# Altium schematic sheet StepMotorAnd Triac.SchDoc
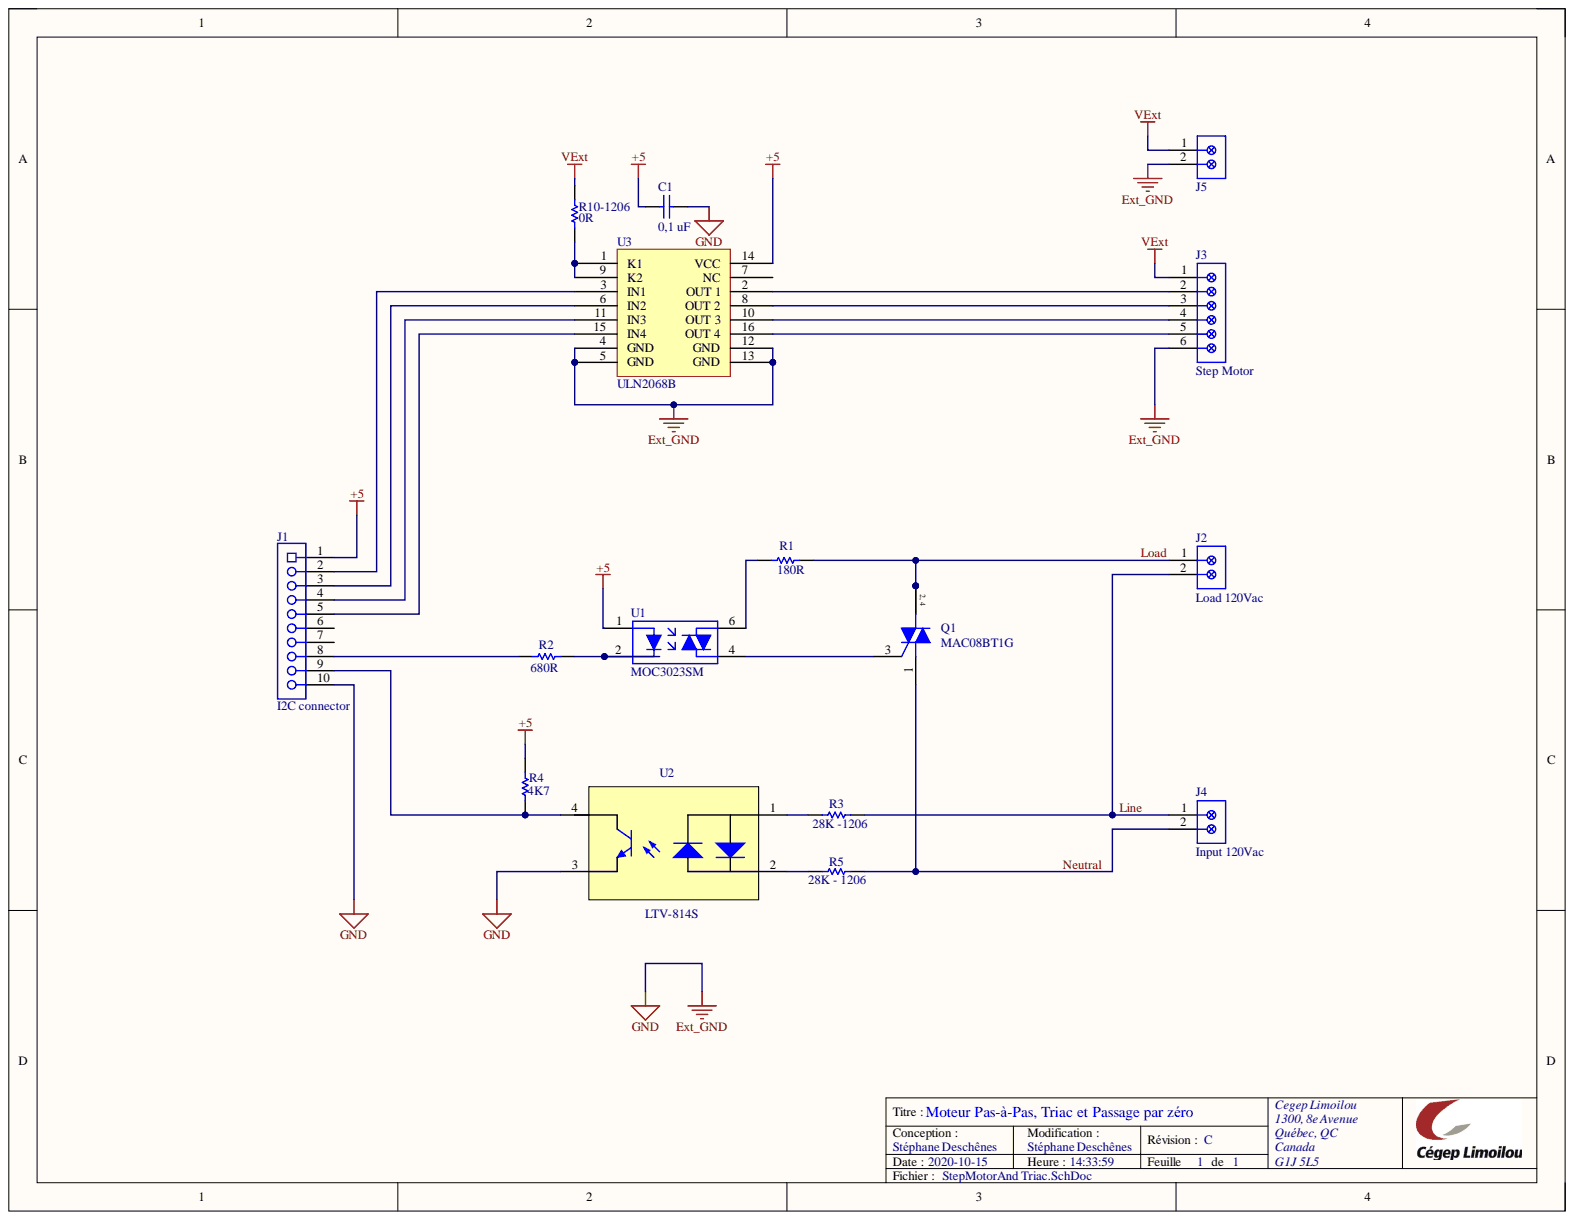
[diagram p0: StepMotorAnd Triac.SchDoc]

## Parts
C1  0,1 uF  [C0805]
J1  I2C connector  [PCB-m0n3ellhq5mdac1bt9wy-1]
J2  Load 120Vac  [SCREW-TERMINAL-2POS-0.2]
J3  Step Motor  [SCREW-TERMINAL-6POS-0.1]
J4  Input 120Vac  [PCB-1yya2zbeuka095bf8bhp-1]
J5  Screw Terminal, 0.1", 2 pos  [SCREW-TERMINAL-2POS-0.1]  (value not drawn)
Q1  MAC08BT1G  [SOT-223]
R1  180R  [R0805]
R2  680R  [R0805]
R3  28K -1206  [R1206]
R4  4K7  [R0805]
R5  28K - 1206  [R1206]
R10-1206  0R  [R1206]
U1  MOC3023SM  [PCB-12867618-1]
U2  LTV-814S  [SMD4-2.54-4.6X6.5MM]
U3  ULN2068B  [POWER DIP-16P]

## Nets
+5: C1.1 J1.1 R4.2 U1.1 U3.14(VCC)
Ext_GND: C1.2 J1.10 J3.6 J5.2 U2.3 U3.4(GND) U3.5(GND) U3.12(GND) U3.13(GND)
VExt: J3.1 J5.1 R10-1206.2
Line: J2.2 J4.1 R3.1
Load: J2.1 Q1.2 Q1.4 R1.2
Neutral: J4.2 Q1.1 R5.1
NetJ1_2: J1.2 U3.3(IN1)
NetJ1_3: J1.3 U3.6(IN2)
NetJ1_4: J1.4 U3.11(IN3)
NetJ1_5: J1.5 U3.15(IN4)
NetJ1_8: J1.8 R2.1
NetJ1_9: J1.9 R4.1 U2.4
NetJ3_2: J3.2 U3.2(OUT_1)
NetJ3_3: J3.3 U3.8(OUT_2)
NetJ3_4: J3.4 U3.10(OUT_3)
NetJ3_5: J3.5 U3.16(OUT_4)
NetQ1_3: Q1.3 U1.4
NetR1_1: R1.1 U1.6
NetR2_2: R2.2 U1.2
NetR3_2: R3.2 U2.1
NetR5_2: R5.2 U2.2
NetR10-1206_1: R10-1206.1 U3.1(K1) U3.9(K2)

Not connected: J1 6 7; U3 7(NC)

## Library data of the parts
C1 = Capacitor SMT: Manufacturer: Murata; Part Number: GRM21BR60J226ME39L; Component Kind: Standard; Library Name: Library-SD.SCHLIB
J1 = THT Vertical Pin Header, 10 pins: THT Vertical Pin Header WR-PHD, Pitch 2.54 mm, Single Row, 10 pins; Manufacturer Part Number: 61301011121; Contact Resistance: 20.0 mΩ; Dielectric Withstanding Voltage: 500 V; Gender: Male; Operating Voltage: 250 V; Pin Pitch: 2.54 mm; Component Kind: Standard; Library Name: Library-SD.SCHLIB; Number of Pins: 10; Octopart URL: https://octopart.com/61301011121-w%C3%BCrth+elektronik-18818159; Operating Temperature: -40.0 °C (min); Orientation: Vertical; Packaging: Bag; REACH SVHC Compliance: No SVHC; RoHS: Compliant
J2 J4 = CMP-751594-7: Conn Terminal Block 2 POS 5.08mm Solder ST Thru-Hole 16A; Component Kind: Standard; Contact Material: Brass; Mounting Style: Through Hole, Screw; OctopartID: 7e60b76ee18ea1a7; Packaging: Bulk; RoHS: Compliant
  J2: Library Name: Library-SD.SCHLIB
  J4: Library Name: CommunityVault
J3 = THT Vertical Pin Header, 6 pins: Conn Term Block 2.54mm 6pos Pcb; Component Kind: Standard; Library Name: Library-SD.SCHLIB
J5 = THT Vertical Pin Header, 2 pins: Conn Terminal Block 2 POS 2.54mm Solder ST Thru-Hole 6A; Color: Green; Component Kind: Standard; Library Name: Library-SD.SCHLIB; OctopartID: ad22650d0fb772e5
Q1 = MAC08: Sensitive Gate Triacs, Silicon Bidirectional Thyristor, 4-Pin SOT-223, Pb-Free, Tape and Reel; Current Rating: 0.8 A; Lead-Free Status: Lead Free; Voltage Rating (DC): 200.0 V; Case/Package: SOT-223; Component Kind: Standard; Halogen Free Status: Halogen Free; Library Name: Library-SD.SCHLIB; Lifecycle Status: Active; Manufacturer Part Number: MAC08BT1G; Mounting Style: Surface Mount; Number of Pins: 4; Octopart Url: https://octopart.com/mac08bt1g-on+semiconductor-361330; OctopartID: be9c77931888615b; Packaging: Cut Tape (CT); RoHS: Compliant
R1 = Resistor 0805: RC Series 0805 0.125 W 1.8 kOhms 5% ±100 ppm/°C SMT Thick Film Chip Resistor; Manufacturer Part Number: RC0805JR-071K8L; Case/Package (SI): 2012; Lead-Free Status: Lead Free; Power Rating: 0.125 W; Resistance: 1800.0 Ω; Voltage Rating (DC): 150.0 V; Case/Package: 0805; Component Kind: Standard; Library Name: Library-SD.SCHLIB; Mounting Style: Surface Mount; Number of Pins: 2; Octopart Url: http://octopart.com/rc0805jr-071k8l-yageo-39811494; OctopartID: 9e58550dc298419f; Packaging: Cut Tape (CT); REACH SVHC Compliance: No SVHC; Resistance Tolerance: ±5%; RoHS: Compliant; Seller Landing  Url: https://octopart-clicks.com/click/track?country=US&ak=8157f166&sig=064cd99&sid=5489&ppid=39811494&vpid=190517147&ct=offers; Size-Height: 0.0005 m; Size-Length: 0.002 m; Size-Width: 0.00125 m
R2 = CMP-9101200-3: RESISTOR, THICK FILM, 680 OHM, 0.5W, 1%; Manufacturer: Panasonic; Manufacturer Part Number: ERJ-P06F6800V; Power max: 1/2 W; Component Kind: Standard; Library Name: Library-SD.SCHLIB; Lifecycle Status: Not Listed by Manufacturer; Octopart Url: https://octopart.com/erj-p06f6800v-panasonic-25834860; OctopartID: da6d12edca6705aa; Operating Temperature: -55 ~ 155°C; Package: 0805; RoHS: Compliant; Tolerance: 1%
R3 R5 R10-1206 = Resistor 1206: RC Series 1206 0.250 W; Manufacturer Part Number: RC0805JR-071K8L; Case/Package (SI): 2012; Lead-Free Status: Lead Free; Power Rating: 0.125 W; Resistance: 1800.0 Ω; Resistance Tolerance: ±5%; Voltage Rating (DC): 150.0 V; Case/Package: 0805; Component Kind: Standard; Library Name: Library-SD.SCHLIB; Mounting Style: Surface Mount; Number of Pins: 2; Octopart Url: http://octopart.com/rc0805jr-071k8l-yageo-39811494; OctopartID: 9e58550dc298419f; Packaging: Cut Tape (CT); REACH SVHC Compliance: No SVHC; RoHS: Compliant; Seller Landing  Url: https://octopart-clicks.com/click/track?country=US&ak=8157f166&sig=064cd99&sid=5489&ppid=39811494&vpid=190517147&ct=offers; Size-Height: 0.0005 m; Size-Length: 0.002 m; Size-Width: 0.00125 m
R4 = Resistor 0805: RC Series 0805 0.125 W 1.8 kOhms 5% ±100 ppm/°C SMT Thick Film Chip Resistor; Manufacturer Part Number: RC0805JR-071K8L; Case/Package (SI): 2012; Lead-Free Status: Lead Free; Power Rating: 0.125 W; Voltage Rating (DC): 150.0 V; Case/Package: 0805; Component Kind: Standard; Library Name: Library-SD.SCHLIB; Mounting Style: Surface Mount; Number of Pins: 2; Octopart Url: http://octopart.com/rc0805jr-071k8l-yageo-39811494; OctopartID: 9e58550dc298419f; Packaging: Cut Tape (CT); REACH SVHC Compliance: No SVHC; Resistance Tolerance: ±5%; RoHS: Compliant; Seller Landing  Url: https://octopart-clicks.com/click/track?country=US&ak=8157f166&sig=064cd99&sid=5489&ppid=39811494&vpid=190517147&ct=offers; Size-Height: 0.0005 m; Size-Length: 0.002 m; Size-Width: 0.00125 m
U1 = CMP-2a88e388ce66f54f-1: Optocoupler Triac AC Output 1 Channel 400VDRM 6-Pin Plastic Dip SMD White Bulk; Input Current: 10.0 mA; Input Voltage (DC): 1.15 V; Isolation Voltage: 5.30 kV; Lead-Free Status: Lead Free; Number of Channels: 1; Output Voltage: 400 V; Case/Package: SOIC; Component Kind: Standard; Library Name: CommunityVault; Lifecycle Status: Active; Manufacturer Part Number: MOC3023SM; Mounting Style: Surface Mount; Number of Circuits: 1; Number of Pins: 6; Octopart URL: http://octopart.com/moc3023sm-fairchild+semiconductor-704904; OctopartID: 2a88e388ce66f54f; Packaging: Tape, Tape & Reel (TR); REACH SVHC Compliance: No SVHC; RoHS: Compliant
U2 = CMP-dc84159b3d200343-2: LTV Series Single Channel 35 V 5000 Vrms Surface Mount Photocoupler - SDIP-4; Manufacturer Part Number: LTV-814S-TA1; Input Voltage (DC): 1.20 V; Lead-Free Status: Lead Free; Number of Channels: 1; Rise Time: 4.00 µs; Component Kind: Standard; Library Name: CommunityVault; Number of Circuits: 1; Octopart URL: http://octopart.com/ltv-814s-ta1-lite-on-1426626; Packaging: Tape & Reel (TR)
U3 = ULN2068B: Transistor ULN2068B Quad NPN Darlington Switches 50Volt 1.5 Amp 16-pin DIP; Component Kind: Standard; Library Name: Library-SD.SCHLIB
